# Altium schematic sheet MCU_Supply.SchDoc
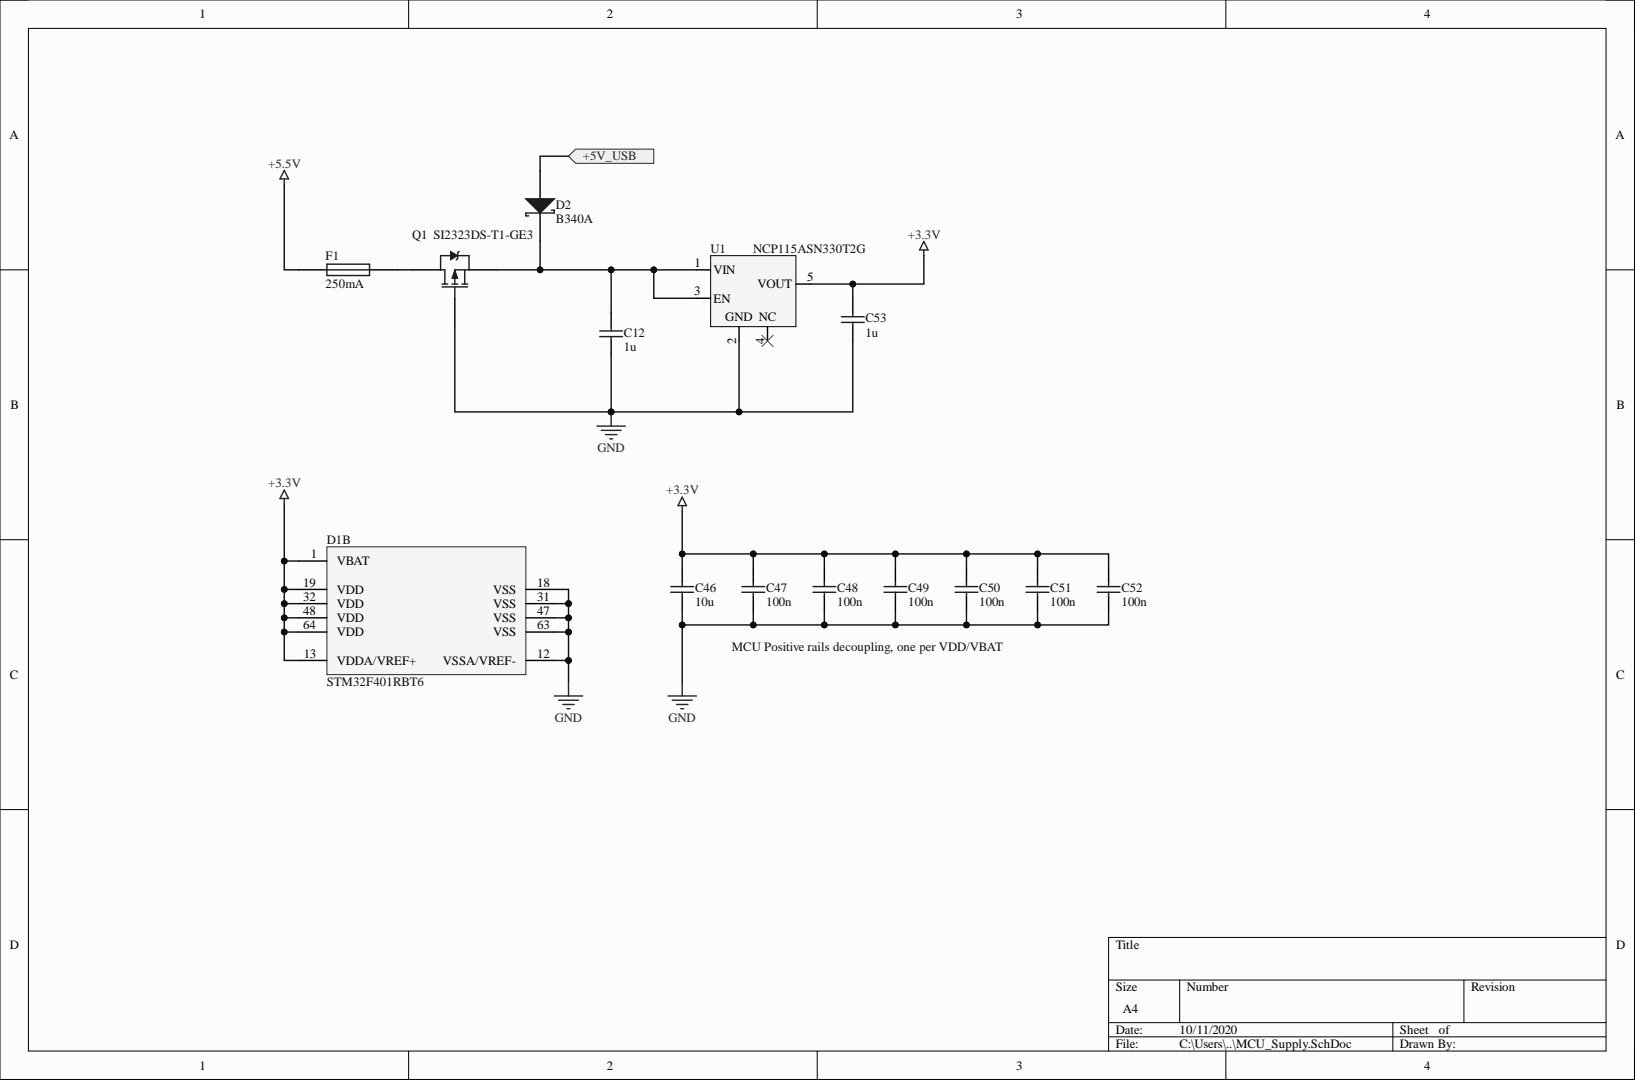
[diagram p0: MCU_Supply.SchDoc]

## Parts
C12  1u
C46  10u
C47  100n
C48  100n
C49  100n
C50  100n
C51  100n
C52  100n
C53  1u
D1  STM32F401RBT6  (part B)
D2  B340A
F1  250mA
Q1  SI2323DS-T1-GE3
U1  NCP115ASN330T2G

## Ports
+5V_USB

## Nets
+3.3V: C46.2 C47.1 C48.2 C49.2 C50.2 C51.2 C52.2 C53.2 D1.1(VBAT) D1.13(VDDA/VREF+) D1.19(VDD) D1.32(VDD) D1.48(VDD) D1.64(VDD) U1.5(VOUT)
+5.5V: F1.1
GND: C12.1 C46.1 C47.2 C48.1 C49.1 C50.1 C51.1 C52.1 C53.1 D1.12(VSSA/VREF-) D1.18(VSS) D1.31(VSS) D1.47(VSS) D1.63(VSS) Q1.1 U1.2
+5V_USB: D2.1 ; port +5V_USB
NetC12_2: C12.2 D2.2 Q1.3 U1.1(VIN) U1.3(EN)
NetF1_2: F1.2 Q1.2

Not connected: U1 4(NC)

## Library data of the parts
C12 C46-C53 = Cap: Component Kind: Standard; Library Name: Miscellaneous Devices.IntLib; Signal Integrity: Cap; Simulation: CAP
D2 = D Schottky: Component Kind: Standard; Library Name: Miscellaneous Devices.IntLib; Signal Integrity: D Schottky; Simulation: SKYDIODE
F1 = Fuse 1: Component Kind: Standard; Library Name: Miscellaneous Devices.IntLib; Simulation: FUSE
Q1 = PMOS-2: Component Kind: Standard; Library Name: Miscellaneous Devices.IntLib; Simulation: IRF9510
U1 = NCP115: Component Kind: Standard; Library Name: Custom_Lib.SchLib
D1 = STM32F401RBT6: Component Kind: Standard; Library Name: STMicroelectronics STM32 F4.IntLib
